# Altium schematic sheet Processor.SchDoc(Processor)
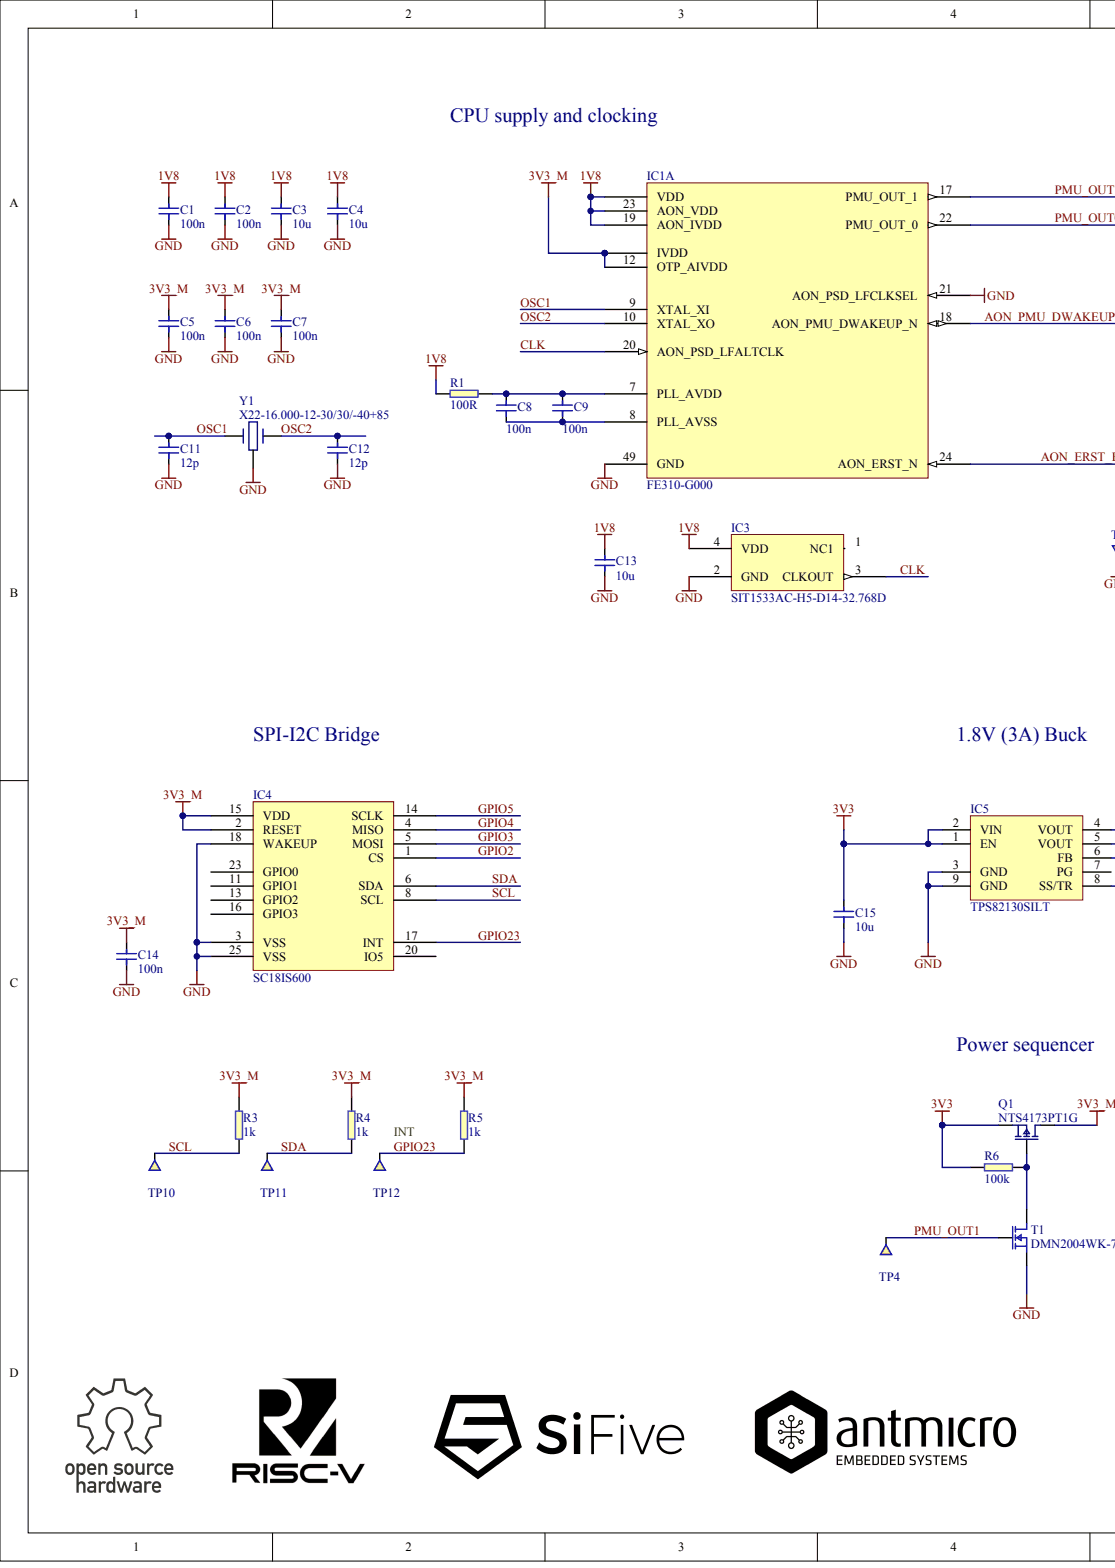
[diagram p0: Processor.SchDoc, left]
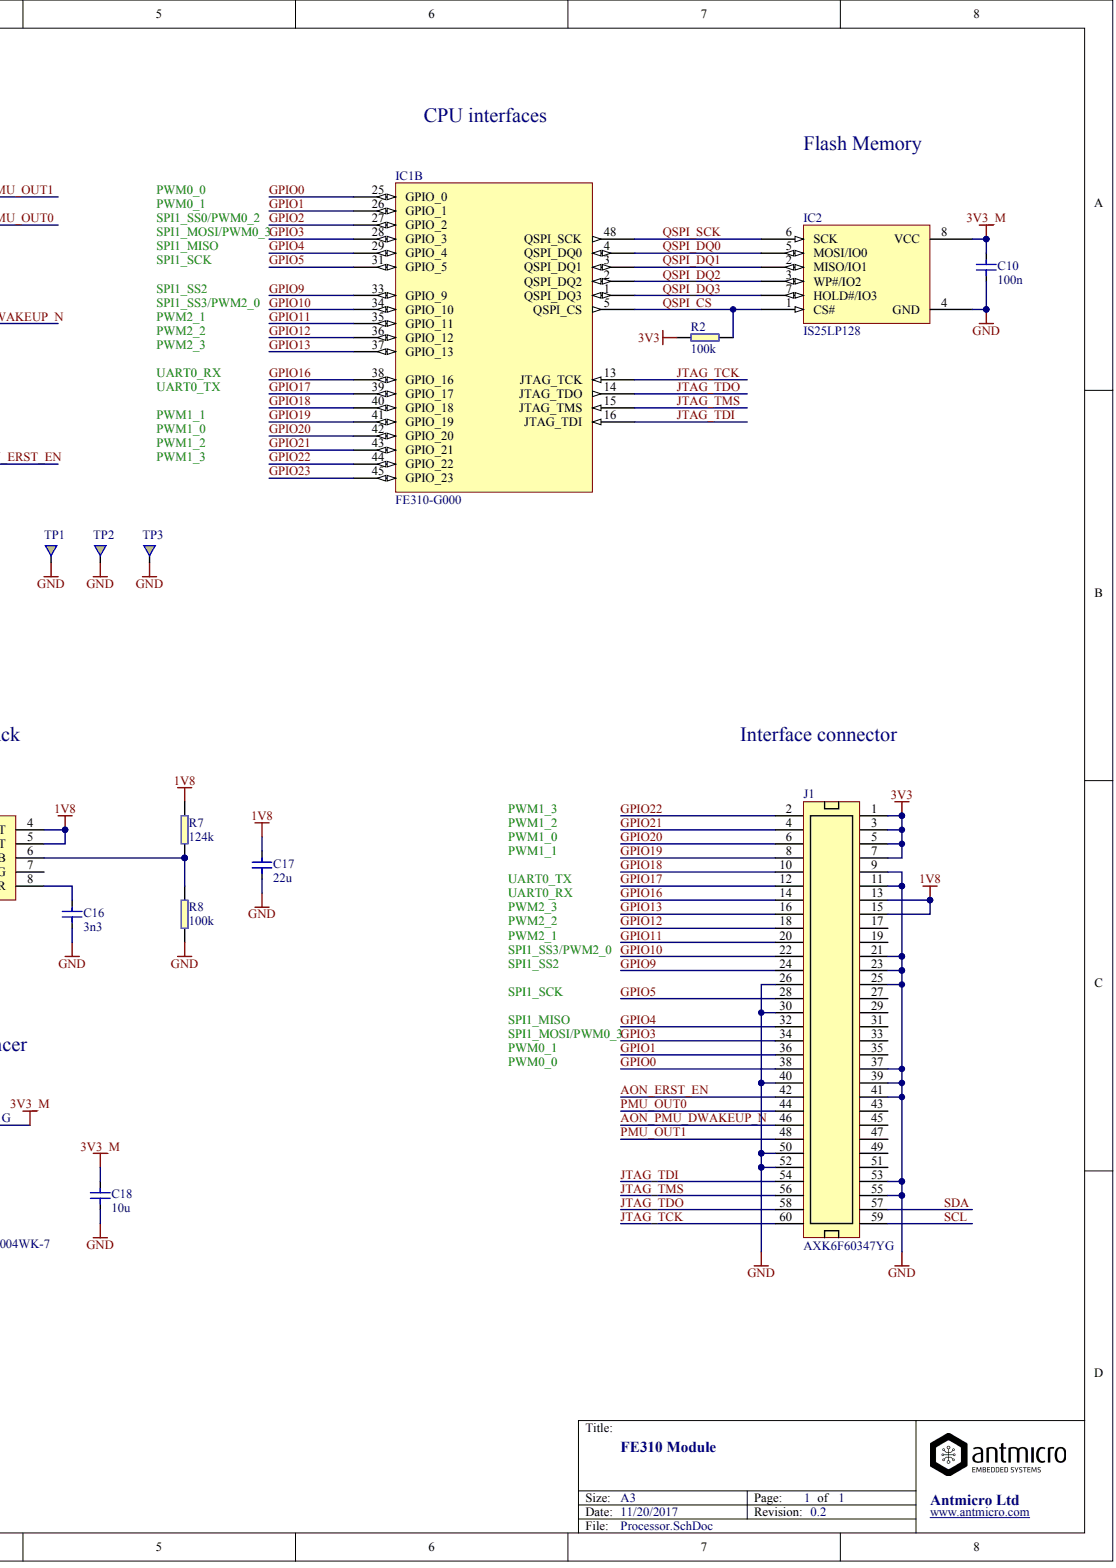
[diagram p1: Processor.SchDoc, right]

## Parts
C1  100n
C2  100n
C3  10u
C4  10u
C5  100n
C6  100n
C7  100n
C8  100n
C9  100n
C10  100n
C11  12p
C12  12p
C13  10u
C14  100n
C15  10u
C16  3n3
C17  22u
C18  10u
IC1  FE310-G000  (parts A,B)
IC2  IS25LP128
IC3  SIT1533AC-H5-D14-32.768D
IC4  SC18IS600
IC5  TPS82130SILT
J1  AXK6F60347YG
Q1  NTS4173PT1G
R1  100R
R2  100k
R3  1k
R4  1k
R5  1k
R6  100k
R7  124k
R8  100k
T1  DMN2004WK-7
TP1  Testpad  (value not drawn)
TP2  Testpad  (value not drawn)
TP3  Testpad  (value not drawn)
TP4  Testpad  (value not drawn)
TP10  Testpad  (value not drawn)
TP11  Testpad  (value not drawn)
TP12  Testpad  (value not drawn)
Y1  X22-16.000-12-30/30/-40+85

## Nets
1V8: C1.2 C2.2 C3.2 C4.2 C13.2 C17.2 IC1.19(AON_IVDD) IC1.23(AON_VDD) IC1.VDD IC3.4(VDD) IC5.4(VOUT) IC5.5(VOUT) J1.13 J1.15 R1.1 R7.1
3V3: C15.2 IC5.1(EN) IC5.2(VIN) J1.1 J1.3 J1.5 J1.7 Q1.2 R2.1 R6.1
3V3_M: C5.2 C6.2 C7.2 C10.2 C14.2 C18.2 IC1.12(OTP_AIVDD) IC1.IVDD IC2.8(VCC) IC4.2(RESET) IC4.15(VDD) Q1.3 R3.1 R4.1 R5.1
GND: C1.1 C2.1 C3.1 C4.1 C5.1 C6.1 C7.1 C10.1 C11.1 C12.1 C13.1 C14.1 C15.1 C16.1 C17.1 C18.1 IC1.21(AON_PSD_LFCLKSEL) IC1.49(GND) IC2.4(GND) IC3.2(GND) IC4.3(VSS) IC4.18(WAKEUP) IC4.25(VSS) IC5.3(GND) IC5.9(GND) J1.9 J1.11 J1.21 J1.23 J1.25 J1.26 J1.30 J1.37 J1.39 J1.40 J1.41 J1.50 J1.52 J1.53 J1.55 R8.2 T1.2 TP1.1 TP2.1 TP3.1 Y1.GND
AON_ERST_EN: IC1.24(AON_ERST_N) J1.42
AON_PMU_DWAKEUP_N: IC1.18(AON_PMU_DWAKEUP_N) J1.46
CLK: IC1.20(AON_PSD_LFALTCLK) IC3.3(CLKOUT)
GPIO0: IC1.25(GPIO_0) J1.38
GPIO1: IC1.26(GPIO_1) J1.36
GPIO2: IC1.27(GPIO_2) IC4.1(CS)
GPIO3: IC1.28(GPIO_3) IC4.5(MOSI) J1.34
GPIO4: IC1.29(GPIO_4) IC4.4(MISO) J1.32
GPIO5: IC1.31(GPIO_5) IC4.14(SCLK) J1.28
GPIO9: IC1.33(GPIO_9) J1.24
GPIO10: IC1.34(GPIO_10) J1.22
GPIO11: IC1.35(GPIO_11) J1.20
GPIO12: IC1.36(GPIO_12) J1.18
GPIO13: IC1.37(GPIO_13) J1.16
GPIO16: IC1.38(GPIO_16) J1.14
GPIO17: IC1.39(GPIO_17) J1.12
GPIO18: IC1.40(GPIO_18) J1.10
GPIO19: IC1.41(GPIO_19) J1.8
GPIO20: IC1.42(GPIO_20) J1.6
GPIO21: IC1.43(GPIO_21) J1.4
GPIO22: IC1.44(GPIO_22) J1.2
GPIO23: IC1.45(GPIO_23) IC4.17(INT) R5.2 TP12.1
JTAG_TCK: IC1.13(JTAG_TCK) J1.60
JTAG_TDI: IC1.16(JTAG_TDI) J1.54
JTAG_TDO: IC1.14(JTAG_TDO) J1.58
JTAG_TMS: IC1.15(JTAG_TMS) J1.56
OSC1: C11.2 IC1.9(XTAL_XI) Y1.1
OSC2: C12.2 IC1.10(XTAL_XO) Y1.3
PMU_OUT0: IC1.22(PMU_OUT_0) J1.44
PMU_OUT1: IC1.17(PMU_OUT_1) J1.48 T1.1 TP4.1
QSPI_CS: IC1.5(QSPI_CS) IC2.1(CS#) R2.2
QSPI_DQ0: IC1.4(QSPI_DQ0) IC2.5(MOSI/IO0)
QSPI_DQ1: IC1.3(QSPI_DQ1) IC2.2(MISO/IO1)
QSPI_DQ2: IC1.2(QSPI_DQ2) IC2.3(WP#/IO2)
QSPI_DQ3: IC1.1(QSPI_DQ3) IC2.7(HOLD#/IO3)
QSPI_SCK: IC1.48(QSPI_SCK) IC2.6(SCK)
SCL: IC4.8(SCL) J1.59 R3.2 TP10.1
SDA: IC4.6(SDA) J1.57 R4.2 TP11.1
NetC8_1: C8.1 C9.1 IC1.8(PLL_AVSS)
NetC8_2: C8.2 C9.2 IC1.7(PLL_AVDD) R1.2
NetC16_2: C16.2 IC5.8(SS/TR)
NetIC5_6: IC5.6(FB) R7.2 R8.1
NetQ1_1: Q1.1 R6.2 T1.3

Not connected: IC3 1; IC4 11(GPIO1) 13(GPIO2) 16(GPIO3) 20(IO5) 23(GPIO0); IC5 7(PG); J1 17 19 27 29 31 33 35 43 45 47 49 51

## Library data of the parts
C1 C2 C5-C10 C14 = (no symbol name): Part Number: C_100n_0402; Manufacturer: MULTICOMP; Part Symbol: MC0402X104M100CT; Value: 100n
C3 C4 C13 C15 C18 = (no symbol name): Part Number: C_10u_0402; Manufacturer: TDK; Part Symbol: C1005X5R0J106M050BC; Value: 10u
C11 C12 = (no symbol name): Part Number: C_12p_0402; Manufacturer: WALSIN; Part Symbol: 0402N120J500CT; Value: 12p
C16 = (no symbol name): Part Number: C_3n3_0402; Manufacturer: MULTICOMP; Part Symbol: MC0402B332K250CT; Value: 3n3
C17 = (no symbol name): Part Number: C_22u_0603; Manufacturer: MURATA; Part Symbol: GRM188R60G226MEA0D; Value: 22u
IC1 = (no symbol name): Manufacturer: FREEDOM; Part Number: FE310-G000; Value: FE310-G000; Part Symbol: FE310-G000
IC2 = (no symbol name): Part Number: IS25LP128-JKLE; Manufacturer: ISSI; Value: IS25LP128; Part Symbol: IS25LP128-JKLE
IC3 = (no symbol name): Manufacturer: SiTIME; Part Number: SIT1533AC-H5-D14-32.768D; Value: SIT1533AC-H5-D14-32.768D; Part Symbol: SIT1533AC-H5-D14-32.768D
IC4 = (no symbol name): Part Number: SC18IS600IBS,151; Manufacturer: NXP; Value: SC18IS600; Part Symbol: SC18IS600IBS,151
IC5 = (no symbol name): Manufacturer: Texas Instruments; Part Number: TPS82130SILT; Value: TPS82130SILT; Part Symbol: TPS82130SILT
J1 = (no symbol name): Manufacturer: PANASONIC; Part Number: AXK6F60347YG; Value: AXK6F60347YG; Part Symbol: AXK6F60347YG
Q1 = (no symbol name): Manufacturer: ON Semiconductor; Part Symbol: NTS4173PT1G; Part Number: NTS4173PT1G; Value: NTS4173PT1G
R1 = (no symbol name): Part Number: R_100R_0402; Manufacturer: BOURNS; Part Symbol: CR0402-FX-1000GLF; Value: 100R
R2 R8 = (no symbol name): Part Number: R_100k_0402; Manufacturer: VISHAY; Part Symbol: CRCW0402100KFKEDHP; Value: 100k
R3-R5 = (no symbol name): Part Number: R_1k_0402; Manufacturer: MULTICOMP; Part Symbol: MCMR04X1001FTL; Value: 1k
R6 = (no symbol name): Part Number: R_100k_0402; Manufacturer: VISHAY; Part Symbol: CRCW0402100KFKEDHP; Tolerance: 1%; Value: 100k; Supplier 1: Farnell; Supplier Part Number 1: 1738877
R7 = (no symbol name): Part Number: R_124k_0402; Manufacturer: WALSIN; Part Symbol: WR04X1240FTL; Value: 124k
T1 = (no symbol name): Manufacturer: DIODES INC.; Value: DMN2004WK-7; Part Number: DMN2004WK-7; Part Symbol: DMN2004WK-7
Y1 = (no symbol name): Part Number: CRYSTAL_16MHz_SMD_2.5x2mm; Manufacturer: MERCURY UNITED ELECTRONICS; Value: X22-16.000-12-30/30/-40+85; Part Symbol: X22-16.000-12-30/30/-40+85
